# Altium schematic sheet Connections.SchDoc(Connections)
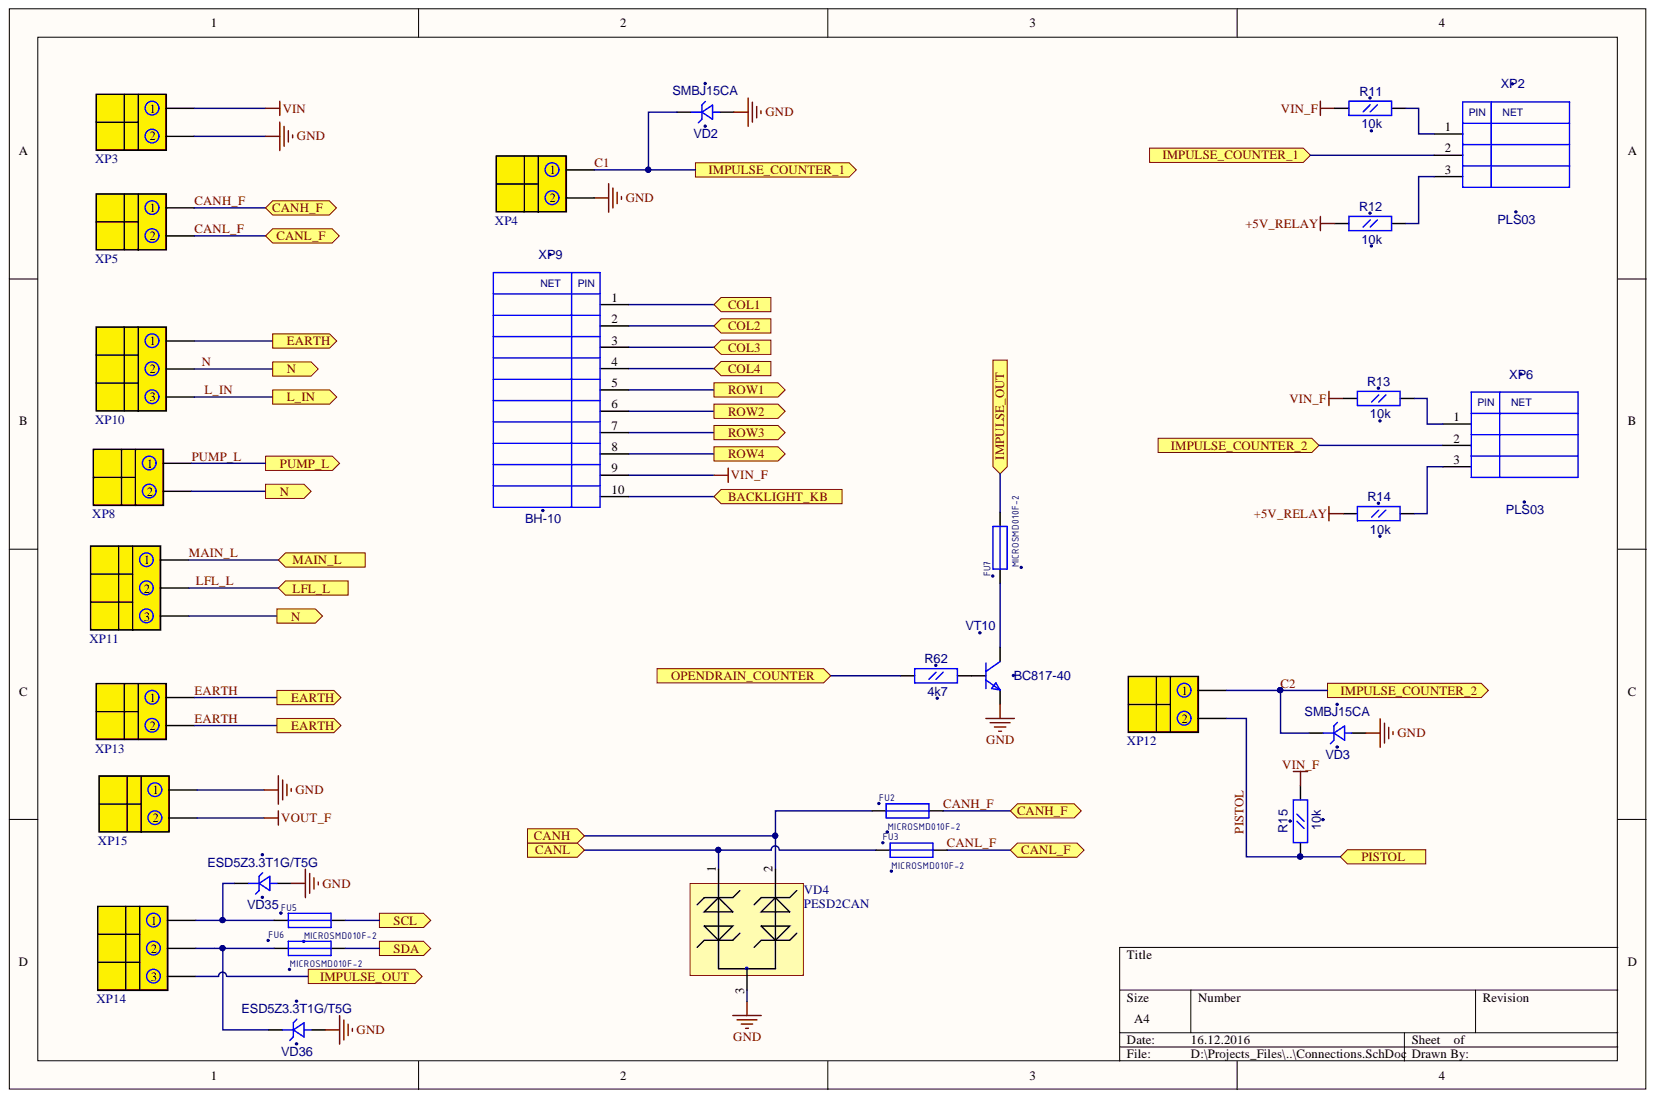
[diagram p0: Connections.SchDoc]

## Parts
FU2  MICROSMD010F-2  [RC1210]
FU3  MICROSMD010F-2  [RC1210]
FU5  MICROSMD010F-2  [RC1210]
FU6  MICROSMD010F-2  [RC1210]
FU7  MICROSMD010F-2  [RC1210]
R11  10k  [RC0603]
R12  10k  [RC0603]
R13  10k  [RC0603]
R14  10k  [RC0603]
R15  10k  [RC0603]
R62  4k7  [RC0603]
VD2  SMBJ15CA  [SMB]
VD3  SMBJ15CA  [SMB]
VD4  PESD2CAN  [SOT-23(123)]
VD35  ESD5Z3.3T1G/T5G  [SOD-523]
VD36  ESD5Z3.3T1G/T5G  [SOD-523]
VT10  BC817-40  [SOT-23(123)]
XP2  PLS03  [PLS-03]
XP3  DG330-2PIN  [DG330-2PIN]  (value not drawn)
XP4  DG330-2PIN  [DG330-2PIN]  (value not drawn)
XP5  DG330-2PIN  [DG330-2PIN]  (value not drawn)
XP6  PLS03  [PLS-03]
XP8  DG330-2PIN  [DG330-2PIN]  (value not drawn)
XP9  BH-10  [BH-10]
XP10  DG330-3PIN  [DG330-3PIN]  (value not drawn)
XP11  DG330-3PIN  [DG330-3PIN]  (value not drawn)
XP12  DG330-2PIN  [DG330-2PIN]  (value not drawn)
XP13  DG330-2PIN  [DG330-2PIN]  (value not drawn)
XP14  DG330-3PIN  [DG330-3PIN]  (value not drawn)
XP15  DG330-2PIN  [DG330-2PIN]  (value not drawn)

## Ports
BACKLIGHT_KB, CANH, CANH_F, CANL, CANL_F, COL1, COL2, COL3, COL4, EARTH, IMPULSE_COUNTER_1, IMPULSE_COUNTER_2, IMPULSE_OUT, L_IN, LFL_L, MAIN_L, N, OPENDRAIN_COUNTER, PISTOL, PUMP_L, ROW1, ROW2, ROW3, ROW4, SCL, SDA

## Nets
+5V_RELAY: R12.1 R14.1
GND: VD2.1 VD3.1 VD4.3 VD35.1 VD36.1 VT10.2 XP3.2 XP4.2 XP15.1
VIN: XP3.1
VIN_F: R11.1 R13.1 R15.2 XP9.9
VOUT_F: XP15.2
BACKLIGHT_KB: XP9.10 ; port BACKLIGHT_KB
C1: VD2.2 XP2.2 XP4.1 ; port IMPULSE_COUNTER_1
C2: VD3.2 XP6.2 XP12.1 ; port IMPULSE_COUNTER_2
CANH: FU2.1 VD4.2 ; port CANH
CANH_F: FU2.2 XP5.1 ; port CANH_F
CANL: FU3.1 VD4.1 ; port CANL
CANL_F: FU3.2 XP5.2 ; port CANL_F
COL1: XP9.1 ; port COL1
COL2: XP9.2 ; port COL2
COL3: XP9.3 ; port COL3
COL4: XP9.4 ; port COL4
EARTH: XP10.1 XP13.1 XP13.2 ; port EARTH
IMPULSE_OUT: FU7.2 XP14.3 ; port IMPULSE_OUT
L_IN: XP10.3 ; port L_IN
LFL_L: XP11.2 ; port LFL_L
MAIN_L: XP11.1 ; port MAIN_L
N: XP8.2 XP10.2 XP11.3 ; port N
OPENDRAIN_COUNTER: R62.1 ; port OPENDRAIN_COUNTER
PISTOL: R15.1 XP12.2 ; port PISTOL
PUMP_L: XP8.1 ; port PUMP_L
ROW1: XP9.5 ; port ROW1
ROW2: XP9.6 ; port ROW2
ROW3: XP9.7 ; port ROW3
ROW4: XP9.8 ; port ROW4
SCL: FU5.2 ; port SCL
SDA: FU6.2 ; port SDA
NetFU5_1: FU5.1 VD35.2 XP14.1
NetFU6_1: FU6.1 VD36.2 XP14.2
NetFU7_1: FU7.1 VT10.3
NetR11_2: R11.2 XP2.1
NetR12_2: R12.2 XP2.3
NetR13_2: R13.2 XP6.1
NetR14_2: R14.2 XP6.3
NetR62_2: R62.2 VT10.1

## Library data of the parts
FU2 FU3 FU5-FU7 = MICROSMD: Component Kind: Standard; Description: MICROSMD; Library Name: gprs_modem_2.SchLib
  FU2 FU3 FU5 FU6: Value: MICROSMD010F-2
R11-R15 R62 = RC0603: Component Kind: Standard; Description: RC0603; Library Name: gprs_modem_2.SchLib
  R11-R15: Value: 10k
  R62: Value: 4k7
VD2 VD3 = SMBJ: Component Kind: Standard; Description: SMBJ; Library Name: gprs_modem_2.SchLib; Value: SMBJ15CA
VD4 = PESD2CAN: TVS for CAN; Component Kind: Standard; Library Name: gprs_modem_2.SchLib
VD35 VD36 = ESD5Z: Supressor; Component Kind: Standard; Library Name: gprs_modem_2.SchLib; Value: ESD5Z3.3T1G/T5G
VT10 = BC846BLT1: NPN 500ma transistor; ComponentField7: Published 29-Sep-2000; Component Kind: Standard; Library Name: gprs_modem_2.SchLib; OriginalName: BC846BLT1; Simulation: BC846BLT1; Value: BC817-40
XP2 XP6 = PLS03: Component Kind: Standard; Description: PLS03; Library Name: gprs_modem_2.SchLib
XP3-XP5 XP8 XP12 XP13 XP15 = DG330-2PIN: Component Kind: Standard; Library Name: gprs_modem_2.SchLib; Value: DG330-2PIN
XP9 = BH-10: Component Kind: Standard; Description: BH-10; Library Name: gprs_modem_2.SchLib
XP10 XP11 XP14 = DG330-3PIN: Component Kind: Standard; Library Name: gprs_modem_2.SchLib; Value: DG330-3PIN
